# Altium schematic sheet PLC_V1.0.SchDoc(PLC_V1.0)
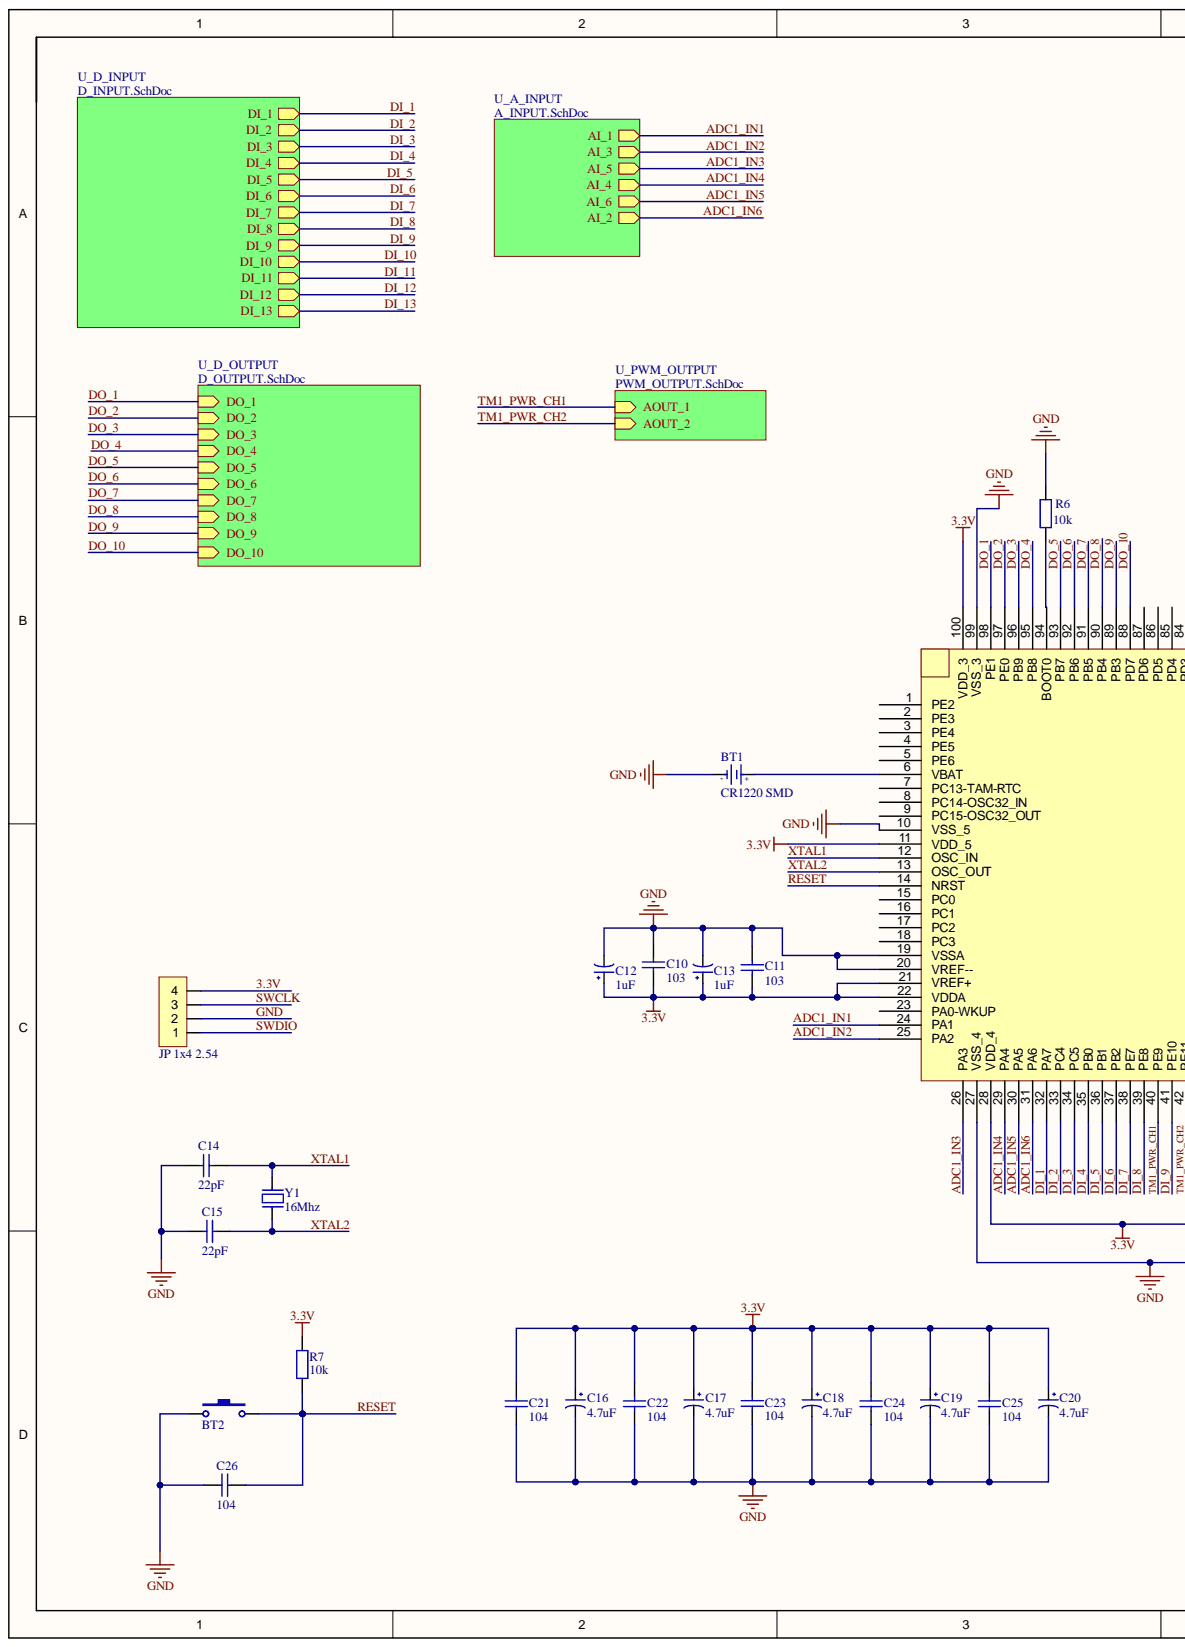
[diagram p0: PLC_V1.0.SchDoc, left]
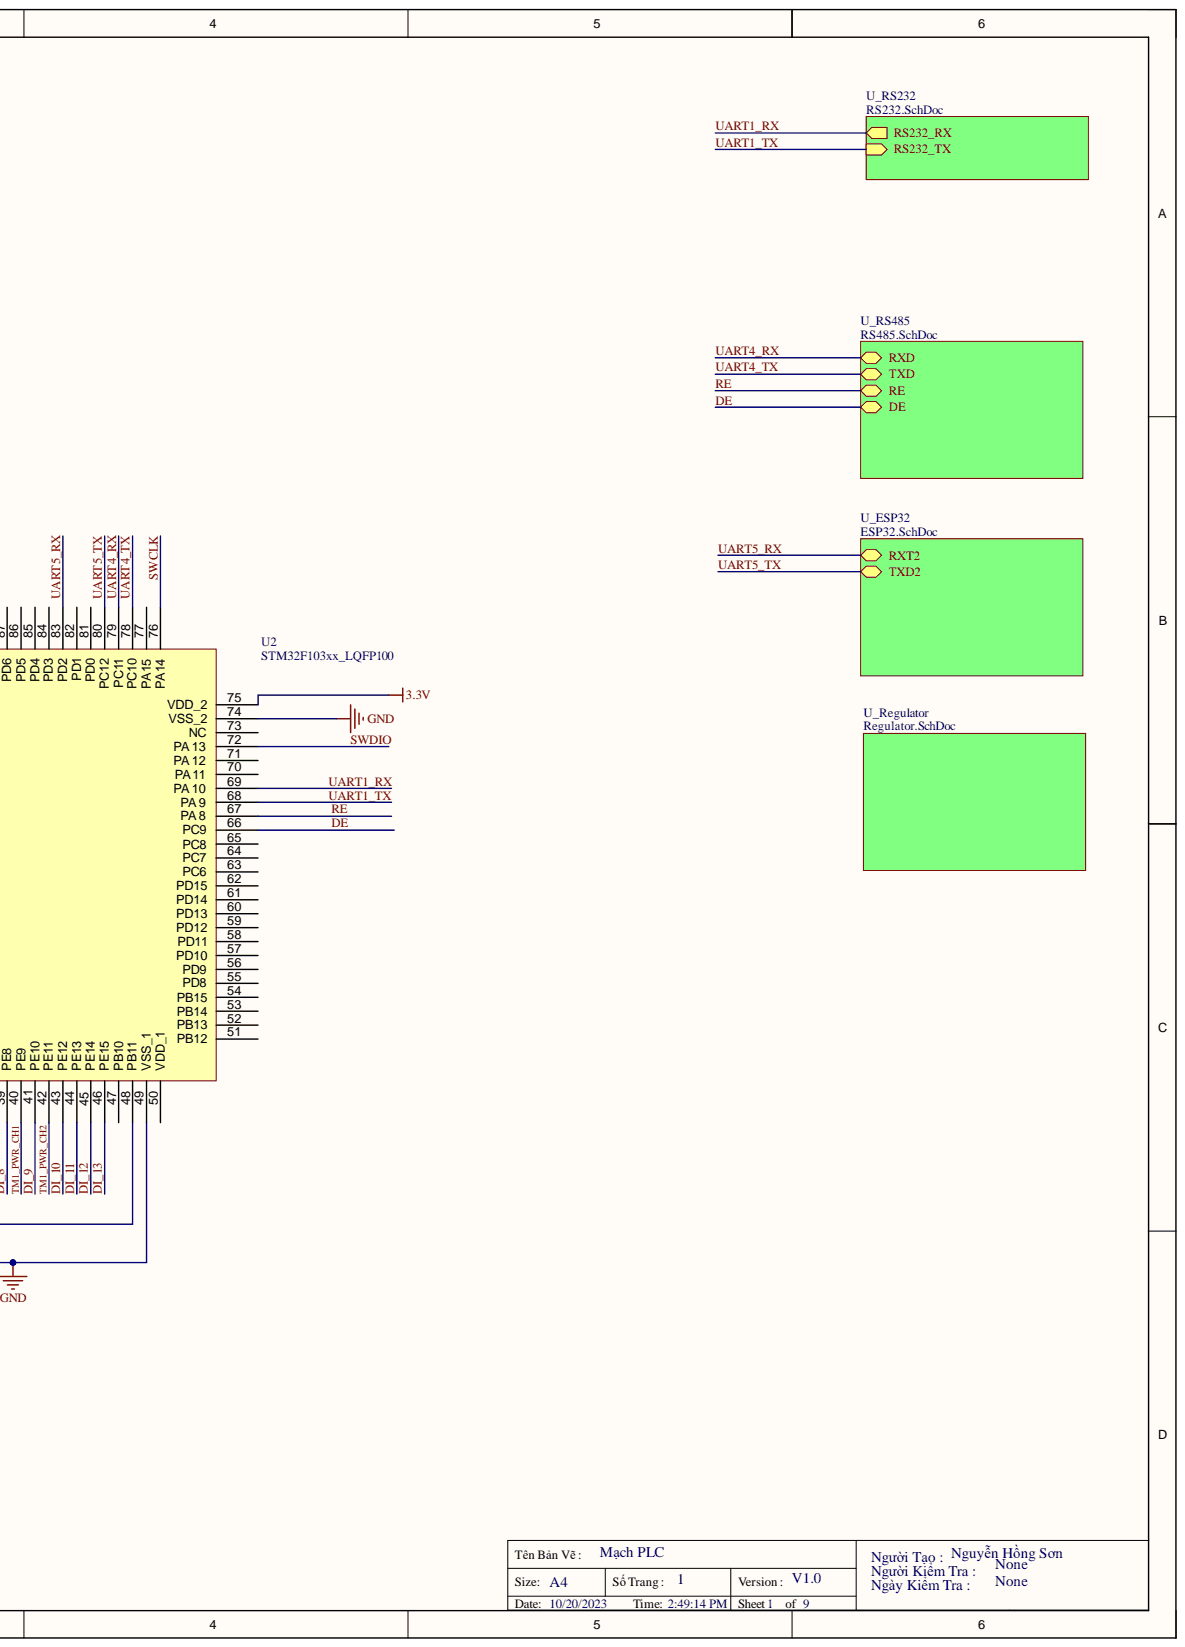
[diagram p1: PLC_V1.0.SchDoc, right]

## Parts
BT1  CR1220 SMD  [DE PIN CR1220]
BT2  Nut Nhan 2 Chan SMD 3x6x2.5  [Nut Nhan 2 Chan SMD 3x6x2.5]  (value not drawn)
C10  103  [tu 0603]
C11  103  [tu 0603]
C12  1uF  [tu tantalum smd3216]
C13  1uF  [tu tantalum smd3216]
C14  22pF  [tu 0805]
C15  22pF  [tu 0805]
C16  4.7uF  [tu tantalum smd6032]
C17  4.7uF  [tu tantalum smd6032]
C18  4.7uF  [tu tantalum smd6032]
C19  4.7uF  [tu tantalum smd6032]
C20  4.7uF  [tu tantalum smd6032]
C21  104  [tu 0805]
C22  104  [tu 0805]
C23  104  [tu 0805]
C24  104  [tu 0805]
C25  104  [tu 0805]
C26  104  [tu 0805]
JP1  JP 1x4 2.54  [JP 1x4 DT]
R6  10k  [tro smd 0805]
R7  10k  [tro smd 0805]
U2  STM32F103xx_LQFP100  [STM32_LQFP100]
Y1  16Mhz  [Thach Anh 2 chan SMD]

## Nets
3.3V: C10.1 C11.1 C12.1 C13.1 C16.1 C17.1 C18.1 C19.1 C20.1 C21.2 C22.2 C23.2 C24.2 C25.2 JP1.4 R7.1 U2.11(VDD_5) U2.21(VREF+) U2.22(VDDA) U2.28(VDD_4) U2.48(PB11) U2.75(VDD_2) U2.100(VDD_3)
ADC1_IN1: U2.24(PA1)
ADC1_IN2: U2.25(PA2)
ADC1_IN3: U2.26(PA3)
ADC1_IN4: U2.29(PA4)
ADC1_IN5: U2.30(PA5)
ADC1_IN6: U2.31(PA6)
DE: U2.66(PC9)
DI_1: U2.32(PA7)
DI_2: U2.33(PC4)
DI_3: U2.34(PC5)
DI_4: U2.35(PB0)
DI_5: U2.36(PB1)
DI_6: U2.37(PB2)
DI_7: U2.38(PE7)
DI_8: U2.39(PE8)
DI_9: U2.41(PE10)
DI_10: U2.43(PE12)
DI_11: U2.44(PE13)
DI_12: U2.45(PE14)
DI_13: U2.46(PE15)
DO_1: U2.98(PE1)
DO_2: U2.97(PE0)
DO_3: U2.96(PB9)
DO_4: U2.95(PB8)
DO_5: U2.93(PB7)
DO_6: U2.92(PB6)
DO_7: U2.91(PB5)
DO_8: U2.90(PB4)
DO_9: U2.89(PB3)
DO_10: U2.88(PD7)
GND: BT1.2 BT2.1 C10.2 C11.2 C12.2 C13.2 C14.2 C15.2 C16.2 C17.2 C18.2 C19.2 C20.2 C21.1 C22.1 C23.1 C24.1 C25.1 C26.2 JP1.2 R6.2 U2.10(VSS_5) U2.19(VSSA) U2.20(VREF--) U2.27(VSS_4) U2.49(VSS_1) U2.74(VSS_2) U2.99(VSS_3)
RE: U2.67(PA_8)
RESET: BT2.2 C26.1 R7.2 U2.14(NRST)
SWCLK: JP1.3 U2.76(PA14)
SWDIO: JP1.1 U2.72(PA_13)
TM1_PWR_CH1: U2.40(PE9)
TM1_PWR_CH2: U2.42(PE11)
UART1_RX: U2.69(PA_10)
UART1_TX: U2.68(PA_9)
UART4_RX: U2.79(PC11)
UART4_TX: U2.78(PC10)
UART5_RX: U2.83(PD2)
UART5_TX: U2.80(PC12)
XTAL1: C14.1 U2.12(OSC_IN) Y1.2
XTAL2: C15.1 U2.13(OSC_OUT) Y1.1
NetBT1_1: BT1.1 U2.6(VBAT)
NetR6_1: R6.1 U2.94(BOOT0)
NetU2_1: U2.1(PE2)
NetU2_2: U2.2(PE3)
NetU2_3: U2.3(PE4)
NetU2_4: U2.4(PE5)
NetU2_5: U2.5(PE6)
NetU2_7: U2.7(PC13-TAM-RTC)
NetU2_8: U2.8(PC14-OSC32_IN)
NetU2_9: U2.9(PC15-OSC32_OUT)
NetU2_15: U2.15(PC0)
NetU2_16: U2.16(PC1)
NetU2_17: U2.17(PC2)
NetU2_18: U2.18(PC3)
NetU2_23: U2.23(PA0-WKUP)
NetU2_47: U2.47(PB10)
NetU2_50: U2.50(VDD_1)
NetU2_51: U2.51(PB12)
NetU2_52: U2.52(PB13)
NetU2_53: U2.53(PB14)
NetU2_54: U2.54(PB15)
NetU2_55: U2.55(PD8)
NetU2_56: U2.56(PD9)
NetU2_57: U2.57(PD10)
NetU2_58: U2.58(PD11)
NetU2_59: U2.59(PD12)
NetU2_60: U2.60(PD13)
NetU2_61: U2.61(PD14)
NetU2_62: U2.62(PD15)
NetU2_63: U2.63(PC6)
NetU2_64: U2.64(PC7)
NetU2_65: U2.65(PC8)
NetU2_70: U2.70(PA_11)
NetU2_71: U2.71(PA_12)
NetU2_73: U2.73(NC)
NetU2_77: U2.77(PA15)
NetU2_81: U2.81(PD0)
NetU2_82: U2.82(PD1)
NetU2_84: U2.84(PD3)
NetU2_85: U2.85(PD4)
NetU2_86: U2.86(PD5)
NetU2_87: U2.87(PD6)

## Library data of the parts
BT1 = De pin CR1220 SMD: Ma_Hang: MH000833; Ten: Đế PIN CR1220 SMD; Component Kind: Standard; Description: CR1220 SMD; Library Name: Altium_Lib_by_KhueNguyenCreator.IntLib
BT2 = Nut Nhan 2 Chan SMD 3x6x2.5: Ma_Hang: MH001036; Ten: Nút Nhấn 2 Chân 3x6x2.5MM SMD; Component Kind: Standard; Description: Nut Nhan 2 Chan SMD 3x6x2.5; Library Name: Altium_Lib_by_KhueNguyenCreator.IntLib
C10 C11 = Tu 0603 103 50V 10%: Dán; Don_Vi: Con; Ma_Hang: MH004567; So_Chan: 2; Ten_Linh_Kien: Tụ 0603 103-10nF 10% 50V; Chung_Loai: Dán; Component Kind: Standard; Library Name: Altium_Lib_by_KhueNguyenCreator.IntLib
C12 C13 = Tu tantalum 1uF 25V SMD3216: Dán; Don_Vi: Con; Ma_Hang: MH007472; So_Chan: 2; Ten_Linh_Kien: Tụ tantalum 105E-1uF 25V SMD3216; Chung_Loai: Dán; Component Kind: Standard; Library Name: Altium_Lib_by_KhueNguyenCreator.IntLib
C14 C15 = Tu 0805 22pF 50V 10%: Dán; Don_Vi: Con; Ma_Hang: MH004560; So_Chan: 2; Ten_Linh_Kien: Tụ 0805 22P 10% 50V; Chung_Loai: Dán; Component Kind: Standard; Library Name: Altium_Lib_by_KhueNguyenCreator.IntLib
C16-C20 = Tu tantalum 4.7uF 35V SMD6032: Dán; Don_Vi: Con; Ma_Hang: MH008687; So_Chan: 2; Ten_Linh_Kien: Tụ tantalum 475V-4.7uF 35V SMD6032; Chung_Loai: Dán; Component Kind: Standard; Library Name: Altium_Lib_by_KhueNguyenCreator.IntLib
C21-C25 = Tu 0805 104 50V 10%: Dán 100nF; Don_Vi: Con; Ma_Hang: MH004551; So_Chan: 2; Ten_Linh_Kien: Tụ 0805 104-100nF 10% 50V; Chung_Loai: Dán; Component Kind: Standard; Library Name: Altium_Lib_by_KhueNguyenCreator.IntLib
C26 = Tu 0805 104 50V 10%: Dán; Don_Vi: Con; Ma_Hang: MH004551; So_Chan: 2; Ten_Linh_Kien: Tụ 0805 104-100nF 10% 50V; Chung_Loai: Dán; Component Kind: Standard; Library Name: Altium_Lib_by_KhueNguyenCreator.IntLib
JP1 = JP 1x4 2.54 Duc Thang: Cắm; Don_Vi: Con; Ma_Hang: MH000903; Ten_Linh_Kien: Jump Đơn Đực 2.54MM 1x40P Thẳng 11MM; Chung_Loai: Cắm; Component Kind: Standard; Library Name: Altium_Lib_by_KhueNguyenCreator.IntLib
R6 R7 = Tro 0805 5% 10k: Dán; Don_Vi: Con; Ma_Hang: MH005239; So_Chan: 2; Ten_Linh_Kien: Trở 0805 5% 10k; Chung_Loai: Dán; Component Kind: Standard; Library Name: Altium_Lib_by_KhueNguyenCreator.IntLib
Y1 = Thach Anh 2 chan SMD: Crystal For all Crystal 2pin.; Value: 4Mhz; Component Kind: Standard; Library Name: Altium_Lib_by_KhueNguyenCreator.IntLib
U2 = STM32F103xx_LQFP100: Component Kind: Standard; Library Name: Altium_Lib_by_KhueNguyenCreator.IntLib
